# Altium schematic sheet main.SchDoc
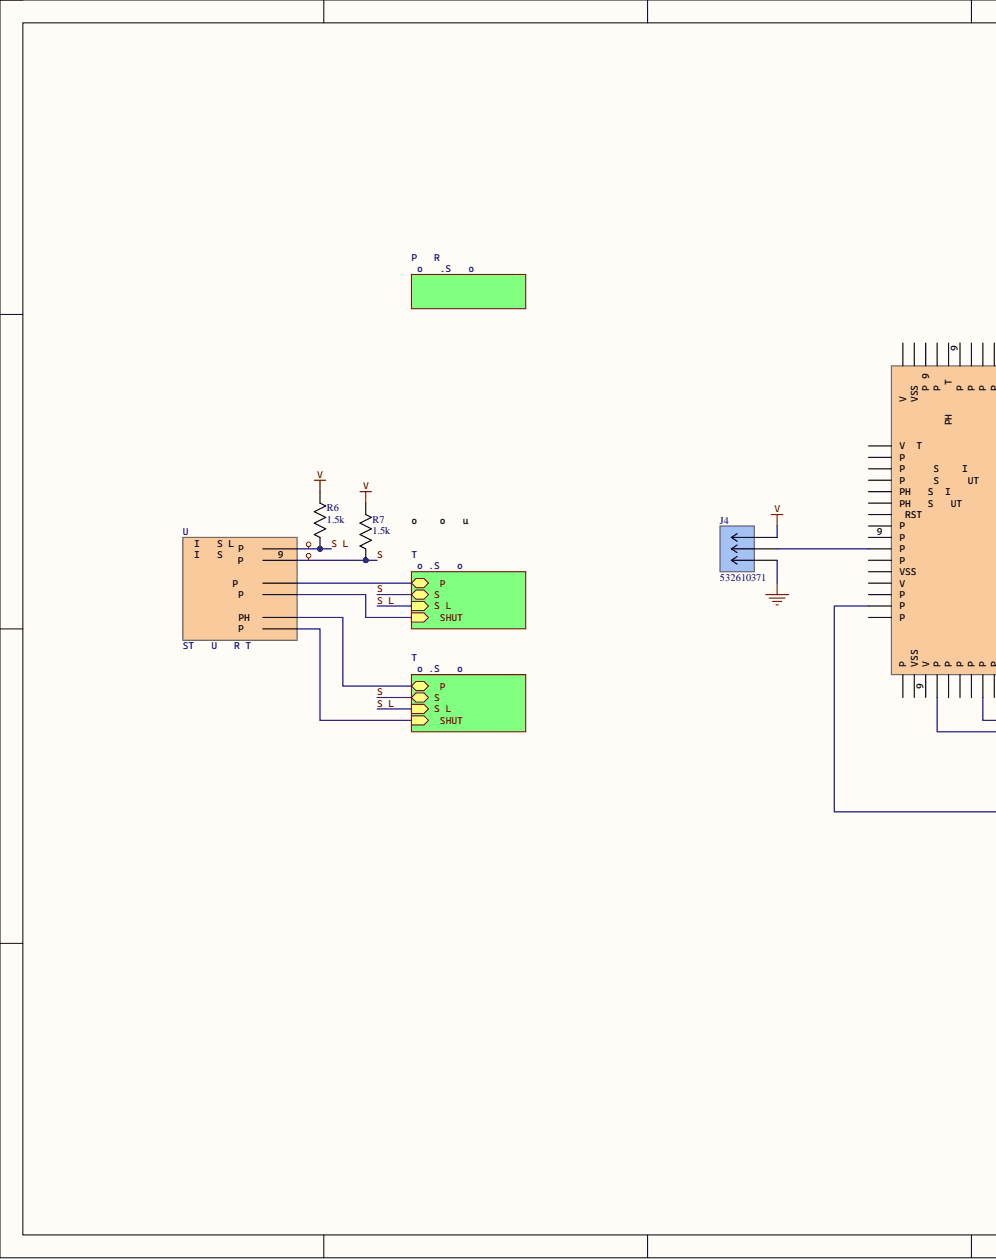
[diagram p0: main.SchDoc, left]
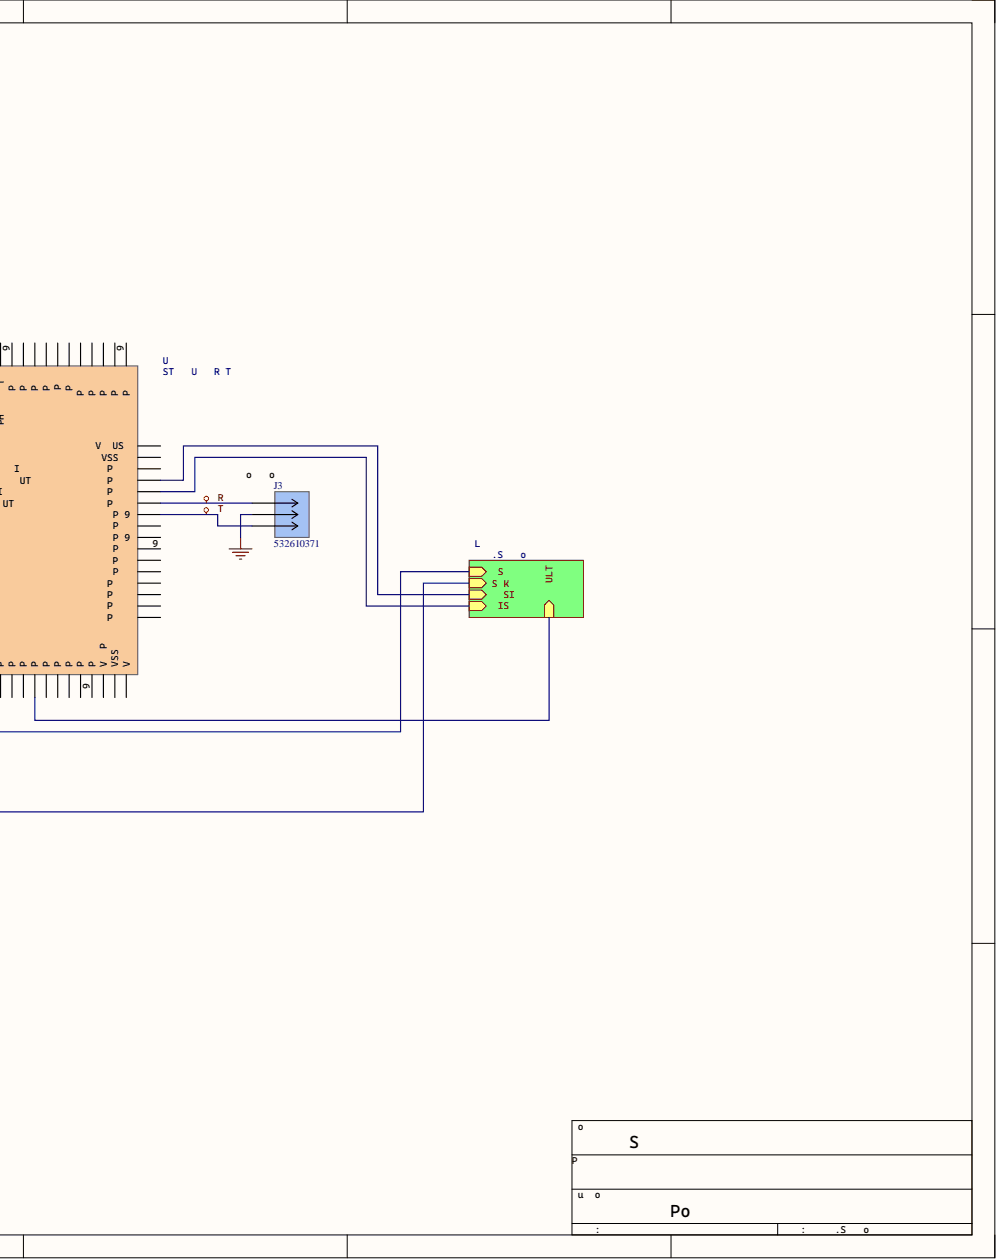
[diagram p1: main.SchDoc, right]

## Parts
J3  532610371  [CONN_532610001-SD_03_MOL]
J4  532610371  [CONN_532610001-SD_03_MOL]
R6  1.5k  [RC0603N_YAG]
R7  1.5k  [RC0603N_YAG]
U0  STM32U575RGT3  [QFP50P1200X1200X160-64N]  (parts A,F)

## Nets
3V3: J4.3 R6.1 R7.1
GND: J3.2 J4.1
RX: J3.1 U0.43(PA10)
SCL: R6.2 U0.58
SDA: R7.2 U0.59
TX: J3.3 U0.42(PA9)
NetJ4_2: J4.2 U0.10(PC2)
NetU0_1: U0.1(VBAT)
NetU0_2: U0.2(PC13)
NetU0_3: U0.3(PC14-OSC32_IN)
NetU0_4: U0.4(PC15-OSC32_OUT)
NetU0_5: U0.5(PH0-OSC_IN)
NetU0_6: U0.6(PH1-OSC_OUT)
NetU0_7: U0.7(NRST)
NetU0_8: U0.8(PC0)
NetU0_9: U0.9(PC1)
NetU0_11: U0.11(PC3)
NetU0_12: U0.12(VSSA)
NetU0_13: U0.13(VDDA)
NetU0_14: U0.14(PA0)
NetU0_15: U0.15(PA1)
NetU0_16: U0.16(PA2)
NetU0_17: U0.17(PA3)
NetU0_18: U0.18(VSS_1)
NetU0_19: U0.19(VDD_1)
NetU0_20: U0.20(PA4)
NetU0_21: U0.21(PA5)
NetU0_22: U0.22(PA6)
NetU0_23: U0.23(PA7)
NetU0_24: U0.24(PC4)
NetU0_25: U0.25(PC5)
NetU0_26: U0.26(PB0)
NetU0_27: U0.27(PB1)
NetU0_28: U0.28(PB2)
NetU0_29: U0.29(PB10)
NetU0_30: U0.30(VCAP)
NetU0_31: U0.31(VSS_2)
NetU0_32: U0.32(VDD_2)
NetU0_33: U0.33(PB12)
NetU0_34: U0.34(PB13)
NetU0_35: U0.35(PB14)
NetU0_36: U0.36(PB15)
NetU0_37: U0.37(PC6)
NetU0_38: U0.38(PC7)
NetU0_39: U0.39(PC8)
NetU0_40: U0.40(PC9)
NetU0_41: U0.41(PA8)
NetU0_44: U0.44(PA11)
NetU0_45: U0.45(PA12)
NetU0_46: U0.46(PA13)
NetU0_47: U0.47(VSS_3)
NetU0_48: U0.48(VDDUSB)
NetU0_49: U0.49(PA14)
NetU0_50: U0.50(PA15)
NetU0_51: U0.51(PC10)
NetU0_52: U0.52(PC11)
NetU0_53: U0.53(PC12)
NetU0_54: U0.54(PD2)
NetU0_55: U0.55(PB3)
NetU0_56: U0.56(PB4)
NetU0_57: U0.57(PB5)
NetU0_58: U0.58
NetU0_59: U0.59
NetU0_60: U0.60(PH3-BOOT0)
NetU0_61: U0.61(PB8)
NetU0_62: U0.62(PB9)
NetU0_63: U0.63(VSS_4)
NetU0_64: U0.64(VDD_3)

## Library data of the parts
J3 J4 = 532610371: 1.25mm Pitch, PicoBlade PCB Header, Single Row, Right-Angle, Surface Mount, Tin (Sn) Plating, Friction Lock, 3 Circuits, Natural, Tape and Reel; Manufacturer_Name: Molex Connector Corporation; Component Kind: Standard; Library Name: 532610371.SchLib; Manufacturer_Part_Number: 532610371; RefDes: RefDes; Type: Type; Copyright: Copyright (C) 2025 Ultra Librarian. All rights reserved.
R6 R7 = RC0603FR-071K5L: 1.5K OHM 1% 1/10W 0603; Manufacturer_Name: Yageo; Component Kind: Standard; Library Name: RT0603BRE07475RL.SchLib; Manufacturer_Part_Number: RC0603FR-071K5L; Copyright: Copyright (C) 2026 Ultra Librarian. All rights reserved.
U0 = STM32U575RGT3: Ultra-low-power Arm Cortex-M33 32-bit MCU+TrustZone+FPU, 240 DMIPS, up to 2 MB Flash memory, 786 KB SRAM; Manufacturer_Name: STMicroelectronics; Geometry.Height: 1.6mm; Component Kind: Standard; Datasheet Link: https://www.st.com/resource/en/datasheet/stm32u575ag.pdf; Library Name: STM32U575RGT3.SchLib; Manufacturer_Part_Number: STM32U575RGT3
